# Altium schematic sheet bldc_dcdc.SchDoc
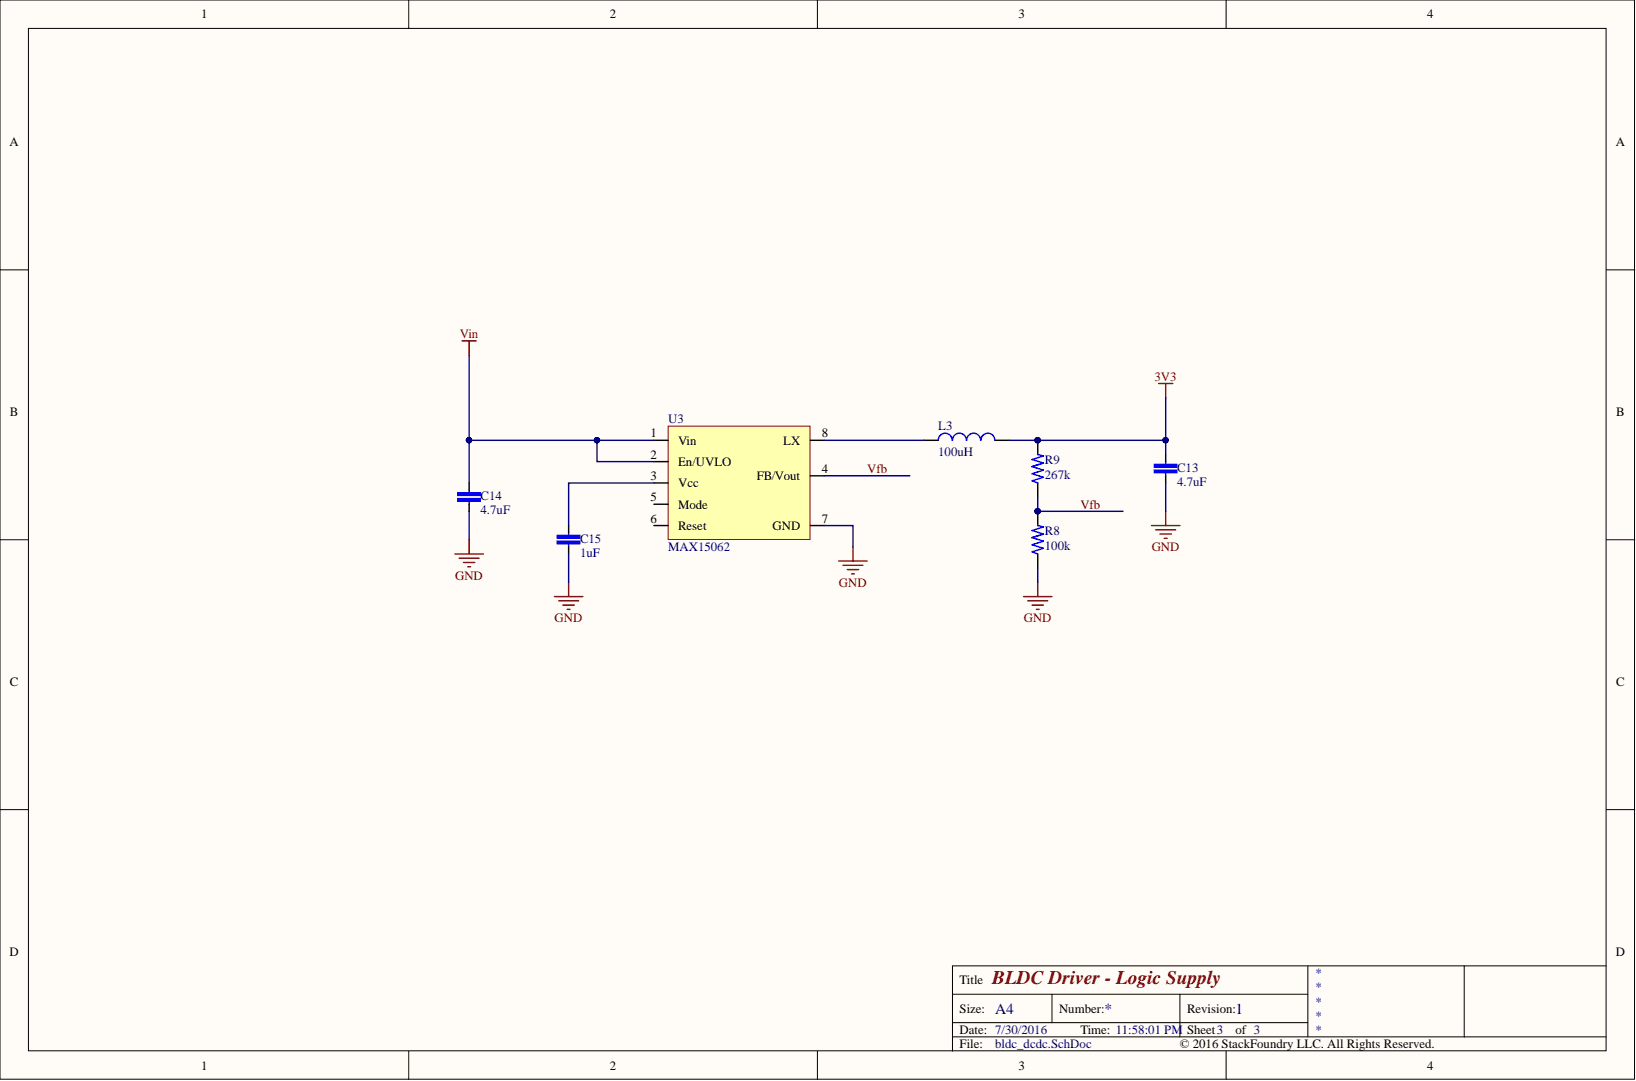
[diagram p0: bldc_dcdc.SchDoc]

## Parts
C13  4.7uF  [C1206]
C14  4.7uF  [C1210]
C15  1uF  [C0603]
L3  100uH  [SNR6045]
R8  100k  [R0402]
R9  267k  [R0402]
U3  MAX15062  [TDFN 8]

## Nets
3V3: C13.2 L3.2 R9.2
GND: C13.1 C14.1 C15.1 R8.1 U3.7(GND)
VIN: C14.2 U3.1(Vin) U3.2(En/UVLO)
VFB: R8.2 R9.1 U3.4(FB/Vout)
NetC15_2: C15.2 U3.3(Vcc)
NetL3_1: L3.1 U3.8(LX)

Not connected: U3 5(Mode) 6(Reset)

## Library data of the parts
C13-C15 = C-EU: Component Kind: Standard; Library Name: rcl.IntLib
L3 = L-US: Component Kind: Standard; Library Name: rcl.IntLib
R8 R9 = R-US: Component Kind: Standard; Library Name: rcl.IntLib
U3 = MAX15062: Component Kind: Standard; Library Name: maxim.IntLib
